# Altium schematic sheet CONNECTORS.SchDoc(CONNECTORS)
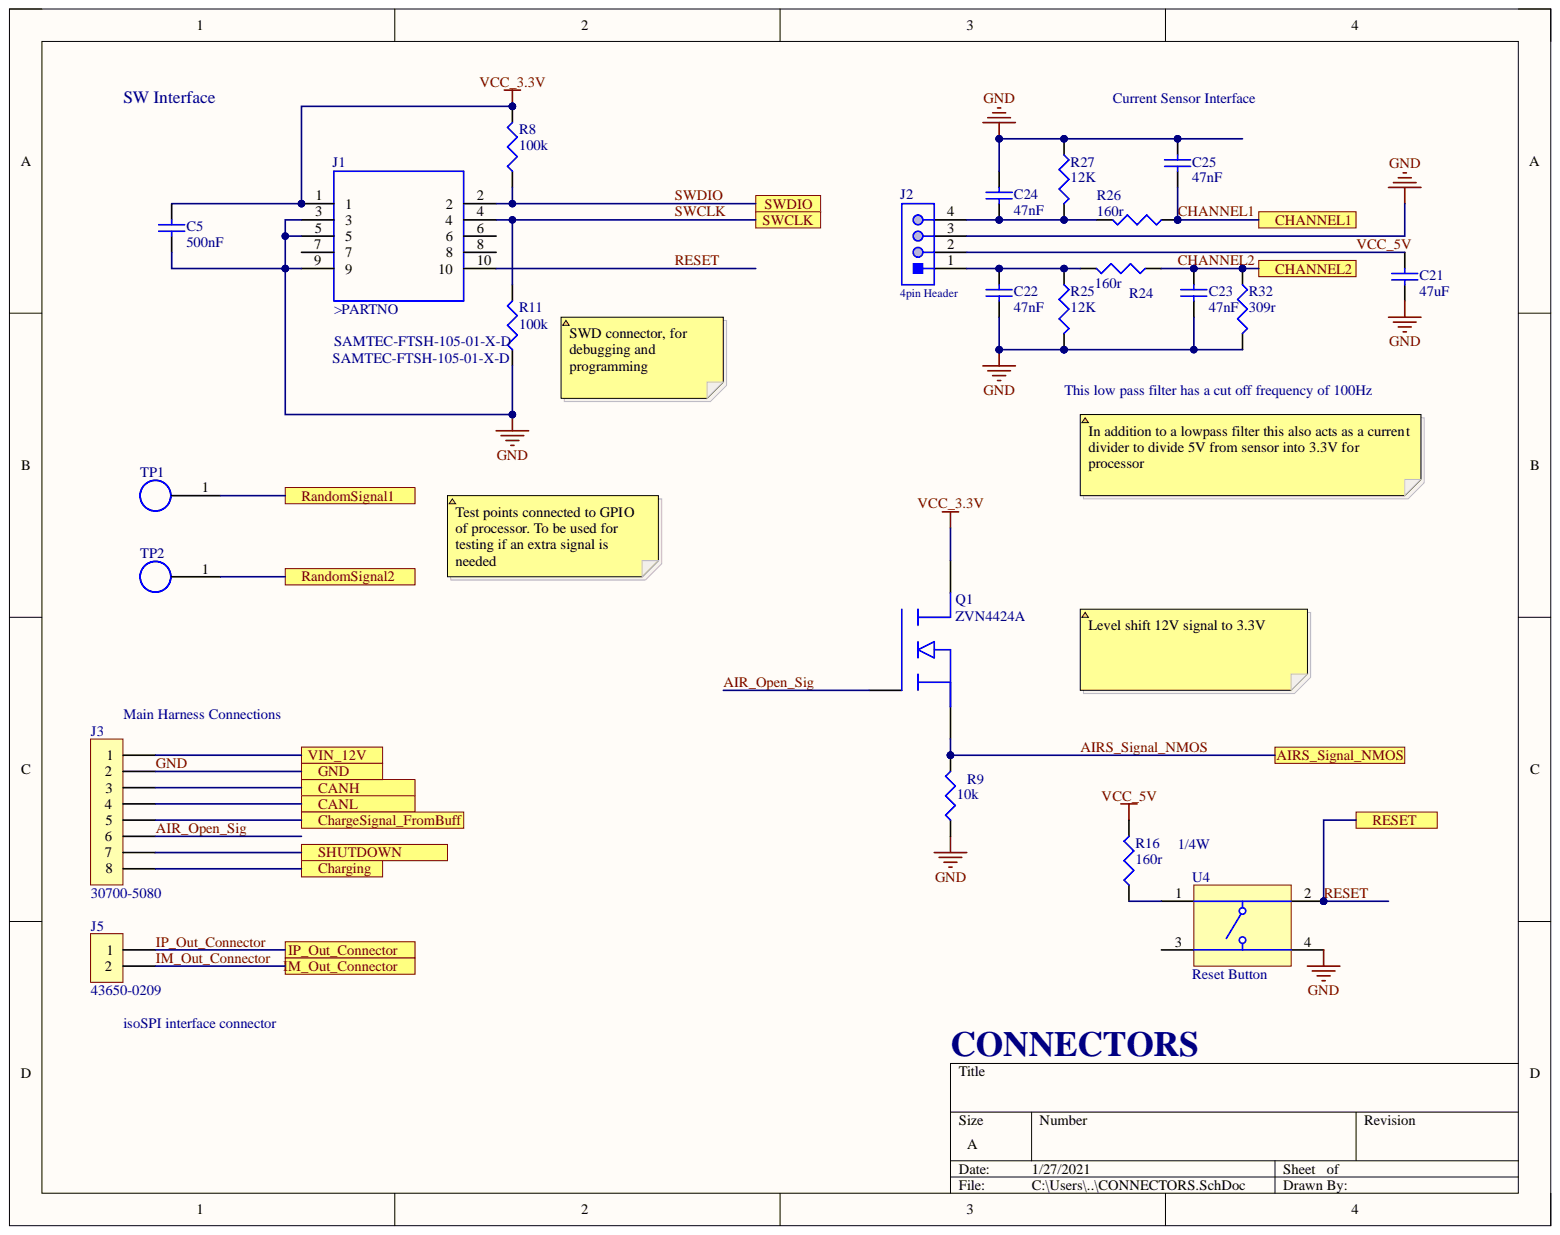
[diagram p0: CONNECTORS.SchDoc]

## Parts
C5  500nF  [Cap, 5mm TH, Ceramic]
C21  47uF  [Cap, 5mm TH, Ceramic]
C22  47nF  [Cap, 5mm TH, Ceramic]
C23  47nF  [Cap, 5mm TH, Ceramic]
C24  47nF  [Cap, 5mm TH, Ceramic]
C25  47nF  [Cap, 5mm TH, Ceramic]
J1  SAMTEC-FTSH-105-01-X-D  [SAMTEC-FTSH-105-01-X-D]
J2  4pin Header  [61300411121]
J3  30700-5080  [30700-5080]
J5  43650-0209  [43650-0209]
Q1  ZVN4424A  [TO-220-KCS0003B_40MIL-DRILL]
R8  100k  [RESAD640W45L370D200 - duplicate]
R9  10k  [RESAD640W45L370D200 - duplicate]
R11  100k  [RESAD640W45L370D200 - duplicate]
R16  160r  [RESAD640W45L370D200 - duplicate]
R24  160r  [Resistor, TH]
R25  12K  [Resistor, TH]
R26  160r  [Resistor, TH]
R27  12K  [Resistor, TH]
R32  309r  [Resistor, TH]
TP1  (no value)  [Test-Point]
TP2  (no value)  [Test-Point]
U4  Reset Button  [TECO-FSM4JSMA_V]

## Ports
AIRS_Signal_NMOS, CANH, CANL, CHANNEL1, CHANNEL2, ChargeSignal_FromBuff, Charging, GND, IM_Out_Connector, IP_Out_Connector, RandomSignal1, RandomSignal2, RESET, SHUTDOWN, SWCLK, SWDIO, VIN_12V

## Nets
VCC_3.3V: C5.2 J1.1 Q1.1 R8.2
AIR_Open_Sig: J3.6 Q1.2
AIRS_Signal_NMOS: Q1.3 R9.2 ; port AIRS_Signal_NMOS
CANH: J3.3 ; port CANH
CANL: J3.4 ; port CANL
CHANNEL1: C25.1 R26.1 ; port CHANNEL1
CHANNEL2: C23.2 R24.1 R32.1 ; port CHANNEL2
ChargeSignal_FromBuff: J3.5 ; port ChargeSignal_FromBuff
Charging: J3.8 ; port Charging
GND: C5.1 C21.1 C22.1 C23.1 C24.2 C25.2 J1.3 J1.5 J1.9 J2.3 J3.2 R9.1 R11.1 R25.2 R27.1 R32.2 U4.4 ; port GND
IM_Out_Connector: J5.2 ; port IM_Out_Connector
IP_Out_Connector: J5.1 ; port IP_Out_Connector
RESET: J1.10 U4.2 ; port RESET
SHUTDOWN: J3.7 ; port SHUTDOWN
SWCLK: J1.4 R11.2 ; port SWCLK
SWDIO: J1.2 R8.1 ; port SWDIO
VCC_5V: C21.2 J2.2 R16.2
VIN_12V: J3.1 ; port VIN_12V
NetC22_2: C22.2 J2.1 R24.2 R25.1
NetC24_1: C24.1 J2.4 R26.2 R27.2
NetR16_1: R16.1 U4.1
N00001: ; port RandomSignal1
N00002: ; port RandomSignal2

Not connected: J1 6 7 8; TP1 1; TP2 1; U4 3

## Library data of the parts
C5 C21-C25 = Cap, 5MM TH, Ceramic: Generic Cap, 5MM TH, Ceramic; TargetLibrary: RITRacing-Passives; Value: Value?; Component Kind: Standard; Library Name: Passives.IntLib; Published: 22Oct2016; Status: Active; Publisher: T.Tallo
J1 = SAMTEC-FTSH-105-01-X-D: Connector Header Through Hole 10 position 0.050" _1.27mm_; MF: Samtec; MP: FTSH-105-01-F-D; Availability: Unavailable; Component Kind: Standard; Library Name: SnapEDAsch.SchLib; Package: None; Price: None; SnapEDA_Link: https://www.snapeda.com/parts/FTSH-105-01-F-D/Samtec%20Inc./view-part/105348/?ref=snap
J2 = CMP-1502-01064-1: THT Vertical Pin Header WR-PHD, Pitch 2.54 mm, Single Row, 4 pins; Manufacturer: Wurth Elektronik; Contact Resistance: 20mOhm; Gender: Male; Pin Number: 4; Pitch: 2.54mm; Rated Current: 3A; Withstanding Voltage: 500VAC; Working Voltage: 250VAC; Component Kind: Standard; Datasheet URL: https://katalog.we-online.de/em/datasheet/6130xx11121.pdf; Manufacturer Part Number: 61300411121; Manufacturer URL: http://www.we-online.de; Mounting Technology: THT; Operating Temperature: -40to105degC; Orientation: Vertical; Package Reference: 61300411121; Packaging: Bag; Part Number: 61300411121; Row Number: Single; Library Name: BMS_MasterboardUpdated.SCHLIB; Publisher: WurthElektronik
J3 = 30700-5080: Molex 8 Position High Density Board Header; TargetLibrary: RITRacing-Connectors; Component Kind: Standard; ComponentLink1Description: Datasheet; ComponentLink1URL: http://www.molex.com/molex/products/datasheet.jsp?part=active/0307004081_PCB_HEADERS.xml; Manufacturer: Molex; PartNumber: 30700-5080; Published: 22Oct2016; Status: Active; Library Name: BMS_MasterboardUpdated_1.SCHLIB; Publisher: T.Tallo
J5 = 43650-0209: Molex 2 Position Board Header, RIght Angle; TargetLibrary: RITRacing-Connectors; Voltage: 600V; Component Kind: Standard; ComponentLink1Description: Datasheet; ComponentLink1URL: http://www.mouser.com/ds/2/418/NG_CD_1445088_A1-614413.pdf; Library Name: Connectors.IntLib; Manufacturer: Molex; PartNumber: 43650-0209; Published: 13Aug2018; Status: Active; Publisher: T.Tallo
Q1 = ZVN4424A: N-Channel MOSFET, 240V, 260mA; Manufacturer: Diodes Inc; TargetLibrary: RITRacing-Transistors; Component Kind: Standard; ComponentLink1Description: Datasheet; ComponentLink1URL: https://www.diodes.com/assets/Datasheets/ZVN4424A.pdf; Library Name: Transistors.IntLib; PartNumber: ZVN4424A; Published: 09/27/2017; Status: Active; Publisher: T.Tallo
R8 R9 R11 R16 R24-R27 R32 = Resistor, TH: Generic TH Resistor; TargetLibrary: RITRacing-Passives; Value: Value?; Component Kind: Standard; Library Name: Passives.IntLib; Published: 22Oct2016; Status: Active; Publisher: T.Tallo
TP1 TP2 = Test-Point: Component Kind: Standard; Library Name: BMS_MasterboardUpdated_1.SCHLIB
U4 = CMP-2000-07488-1: Swicth, SPST, 24 V, -35 to 85 degC, 4-Pin SMD, RoHS, Tape and Reel; Manufacturer: TE Connectivity; Actuator Length: 0.055inch; Actuator Material: Polyamide; Case\/Package: SMD/SMT; Contact Current Rating: 50mA; Contact Resistance: 100mOhm; Electrical Life: 100000Cycles; Illumination Type: Illuminated; Max Voltage Rating (DC): 12V; Operating Force: 1.57N; Switch Travel: 0.25mm; Voltage Rating: 24V; Component Kind: Standard; Contact Material: CopperAlloy; Contact Plating: Silver; Current Rating: 50mA; Datasheet URL: http://www.te.com/commerce/DocumentDelivery/DDEController?Action=showdoc&DocId=Customer+Drawing%7F2-1437565-7%7FV%7Fpdf%7FEnglish%7FENG_CD_2-1437565-7_V.pdf%7F4-1437565-2; Datasheet Version: Rev. V, 02/2015; Length: 6mm; Library Name: Altium Content Vault; Manufacturer URL: http://www.te.com/; Max Operating Temperature: 85degC; Mechanical Life: 100000Cycles; Min Operating Temperature: -35degC; Mount: SurfaceMount; Mounting Technology: SurfaceMount; Orientation: Straight; Package Description: 4-Pin Surface Mount Device, Body 6 x 6 mm, Pitch 4.5 mm; Package Quantity: 750; Package Reference: FSM4JSMA; Packaging: LoosePiece; REACH SVHC: Unknown; RoHSCompliant: true; Termination: GullWing; Throw Configuration: SPST; Voltage Rating (DC): 24V; Width: 6mm
